# Altium schematic sheet Power.SchDoc
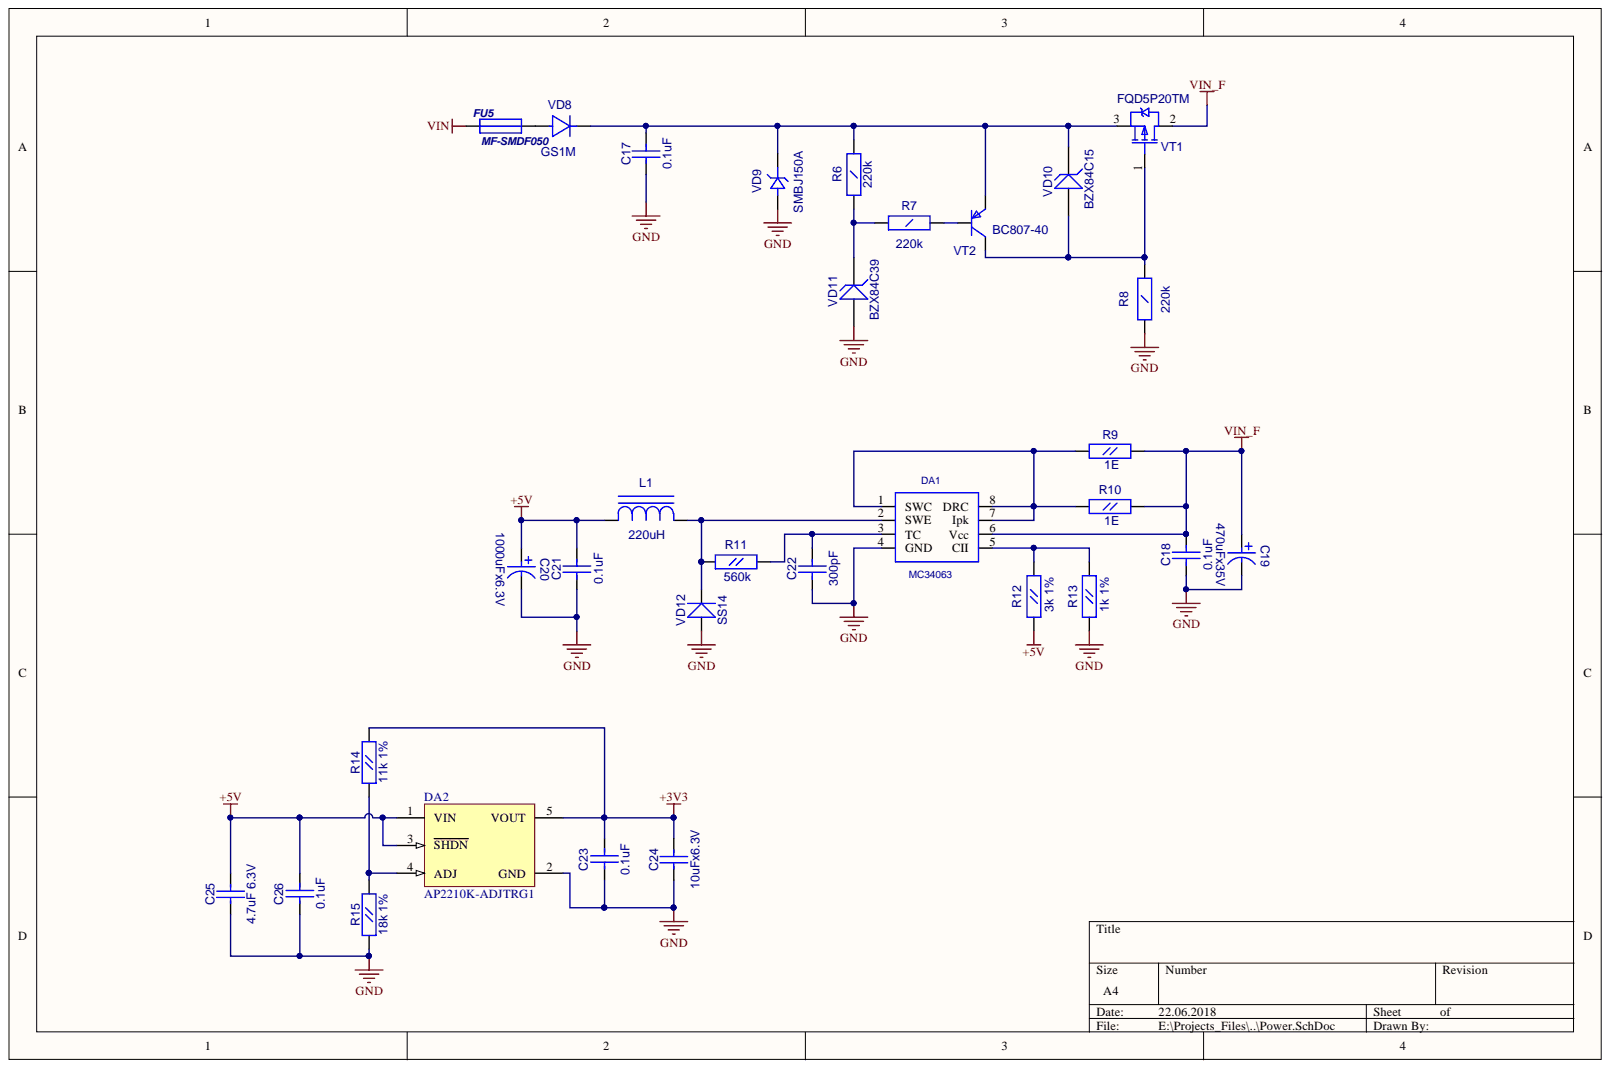
[diagram p0: Power.SchDoc]

## Parts
C17  0.1uF  [CC1206]
C18  0.1uF  [CC0603]
C19  470uFx35V  [CC16X10_CAP0.5(1)(Primary)]
C20  1000uFx6.3V  [CC8X3.5]
C21  0.1uF  [CC0603]
C22  300pF  [CC0603]
C23  0.1uF  [CC0603]
C24  10uFx6.3V  [CC0805]
C25  4.7uF 6.3V  [CC0805]
C26  0.1uF  [CC0603]
DA1  MC34063  [SO-8]
DA2  AP2210K-ADJTRG1  [SOT23-5]
FU5  MF-SMDF050  [MF-SMDF]
L1  220uH  [SDR0805]
R6  220k  [RC1206]
R7  220k  [RC1206]
R8  220k  [RC1206]
R9  1E  [RC0805]
R10  1E  [RC0805]
R11  560k  [RC0603]
R12  3k 1%  [RC0603]
R13  1k 1%  [RC0603]
R14  11k 1%  [RC0603]
R15  18k 1%  [RC0603]
VD8  GS1M  [SMA]
VD9  SMBJ150A  [SMB]
VD10  BZX84C15  [SOT-23(123)]
VD11  BZX84C39  [SOT-23(123)]
VD12  SS14  [SMA]
VT1  FQD5P20TM  [D-PAK(TO-252AA)]
VT2  BC807-40  [SOT-23(123)]

## Nets
+3V3: C23.1 C24.2 DA2.5(VOUT) R14.2
+5V: C20.1 C21.2 C25.2 C26.1 DA2.1(VIN) DA2.3(SHDN) L1.1 R12.1
GND: C17.1 C18.1 C19.1 C20.2 C21.1 C22.1 C23.2 C24.1 C25.1 C26.2 DA1.4(GND) DA2.2(GND) R8.2 R13.1 R15.1 VD9.1 VD11.1 VD12.1
VIN: FU5.1
VIN_F: C18.2 C19.2 DA1.6(Vcc) R9.2 R10.2 VT1.2
NetC17_2: C17.2 R6.2 VD8.2 VD9.2 VD10.3 VT1.3 VT2.2
NetC22_2: C22.2 DA1.3(TC) R11.2
NetDA1_1: DA1.1(SWC) DA1.7(Ipk) DA1.8(DRC) R9.1 R10.1
NetDA1_2: DA1.2(SWE) L1.2 R11.1 VD12.2
NetDA1_5: DA1.5(CII) R12.2 R13.2
NetDA2_4: DA2.4(ADJ) R14.1 R15.2
NetFU5_2: FU5.2 VD8.1
NetR6_1: R6.1 R7.1 VD11.3
NetR7_2: R7.2 VT2.1
NetR8_1: R8.1 VD10.1 VT1.1 VT2.3

## Library data of the parts
C17 = CC1206: Component Kind: Standard; Description: CC1206; Value: 0.1uF; Library Name: gprs_modem_2.SchLib
C18 C21-C23 C26 = CC0603: Component Kind: Standard; Description: CC0603; Library Name: gprs_modem_2.SchLib
  C18 C21 C23 C26: Value: 0.1uF
  C22: Value: 300pF
C19 = CAP0.5(1): Component Kind: Standard; Description: CAP0.5(1); Value: 470uFx35V; Library Name: gprs_modem_2.SchLib
C20 = CAP0.35: Component Kind: Standard; Description: CAP0.35; Value: 1000uFx6.3V; Library Name: gprs_modem_2.SchLib
C24 C25 = CC0805: Component Kind: Standard; Description: CC0805; Library Name: gprs_modem_2.SchLib
  C24: Value: 10uFx6.3V
  C25: Value: 4.7uF 6.3V
DA2 = MCP1824T-ADJE/OT: 300 mA, Low Voltage, Low Quiescent Current LDO Regulator, 5-Pin SOT-223, Extended Temperature, Tape and Reel; Component Kind: Standard; ComponentLink1Description: Manufacturer Link; ComponentLink1URL: http://www.microchip.com; ComponentLink2Description: Datasheet; ComponentLink2URL: http://ww1.microchip.com/downloads/en/DeviceDoc/22070a.pdf; ComponentLink3Description: Packaging Specification; ComponentLink3URL: http://ww1.microchip.com/downloads/en/PackagingSpec/00049AW.pdf; DatasheetVersion: revA, Aug-2007; PackageDescription: 5-Lead Plastic Small Outline Transistor (DC) [SOT-223]; PackageReference: SOT-223-DC5; PackageVersion: revAW, Oct-2008; Published: 29-Jan-2009; Library Name: gprs_modem_2.SchLib; Publisher: Altium Limited
FU5 = MF-SMDF: Component Kind: Standard; Description: MF-SMDF; Value: MF-SMDF050; Library Name: gprs_modem_2.SchLib
L1 = SDR0805: Component Kind: Standard; Description: SDR0805; Value: 220uH; Library Name: gprs_modem_2.SchLib
R6-R8 = RC1206: Component Kind: Standard; Description: RC1206; Value: 220k; Library Name: gprs_modem_2.SchLib
R9 R10 = RC0805: Component Kind: Standard; Description: RC0805; Value: 1E; Library Name: gprs_modem_2.SchLib
R11-R15 = RC0603: Component Kind: Standard; Description: RC0603; Library Name: gprs_modem_2.SchLib
  R11: Value: 560k
  R12: Value: 3k 1%
  R13: Value: 1k 1%
  R14: Value: 11k 1%
  R15: Value: 18k 1%
VD8 = GS1M: Value: {Value}; Component Kind: Standard; Description: GS1M; Library Name: gprs_modem.SchLib
VD9 = SMBJ: Component Kind: Standard; Description: SMBJ; Value: SMBJ150A; Library Name: gprs_modem_2.SchLib
VD10 VD11 = BZX84: Zener Voltage Regulator Diode; Value: {Value}; Component Kind: Standard; Library Name: gprs_modem_2.SchLib
VD12 = SS14: Schottky Rectifier; ComponentField6: Published 8-Jun-2000, Modified 10-July-2000: SIM Model Added.; OriginalName: 10BQ100; Component Kind: Standard; Simulation: 10BQ100; Value: SS14; Library Name: gprs_modem_2.SchLib
VT1 = IRFR9220: HEXFET Power MOSFET; ComponentField7: Published 8-Jun-2000; OriginalName: IRLML5103; Component Kind: Standard; Value: FQD5P20TM; Library Name: gprs_modem_2.SchLib
VT2 = BC857B: ComponentField7: Published 29-Sep-2000; OriginalName: BC846BLT1; Component Kind: Standard; Description: BC857B; Simulation: BC846BLT1; Value: BC807-40; Library Name: gprs_modem.SchLib
DA1 = MC34063: Component Kind: Standard; Description: MC34063; Library Name: Schetchik.SchLib
